# Altium schematic sheet LM5085.SchDoc
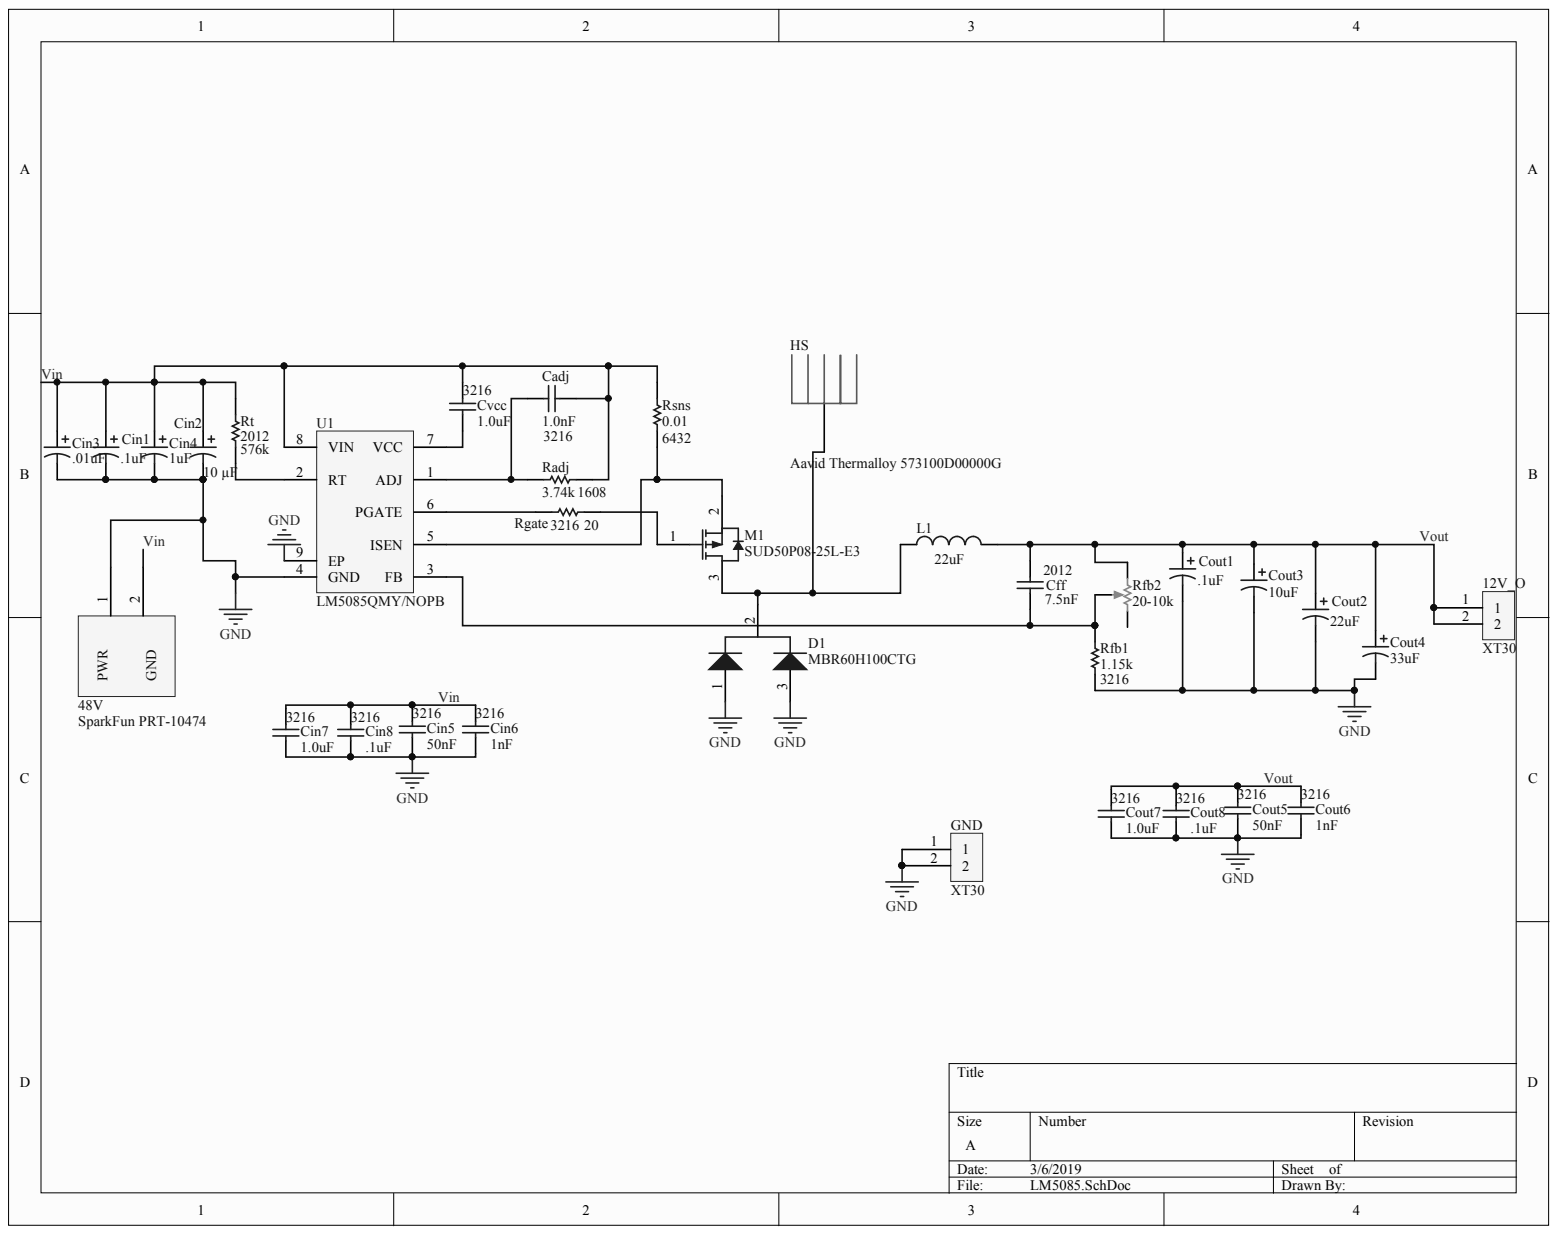
[diagram p0: LM5085.SchDoc]

## Parts
12V_O  XT30  [PCB-8h6goupi0sbkduz6cbnu-1]
48V  SparkFun PRT-10474  [PCB-0yod6kgj5trn1afdndpj-1]
Cadj  1.0nF  Case/Package (SI): 3216  [CAPC3216X94X50ML20T25]
Cff  Capacitance: 7.5nF  Case/Package (SI): 2012  [CAPC2013X95X45LL10T25]  (hidden comment: Murata GRM2195C1H752JA01D)
Cin1  Capacitance: .1uF  [PCB-2jf9nlde2fxplectirwc-1]  (hidden comment: Cap Pol2)
Cin2  AVX TAP106K010SCS  [PCB-qqn37rirklfs29ms14yu-1]  (value not drawn)
Cin3  Capacitance: .01uF  [PCB-2jf9nlde2fxplectirwc-1]  (hidden comment: Cap Pol2)
Cin4  Capacitance: 1uF  [PCB-2jf9nlde2fxplectirwc-1]  (hidden comment: Cap Pol2)
Cin5  50nF  Case/Package (SI): 3216  [CAPC3216X178X50LL20T25]
Cin6  1nF  Case/Package (SI): 3216  [CAPC3216X178X50LL20T25]
Cin7  1.0uF  Case/Package (SI): 3216  [CAPC3216X178X50LL20T25]
Cin8  .1uF  Case/Package (SI): 3216  [CAPC3216X178X50LL20T25]
Cout1  Capacitance: .1uF  [PCB-2jf9nlde2fxplectirwc-1]  (hidden comment: Cap Pol2)
Cout2  Capacitance: 22uF  [PCB-2jf9nlde2fxplectirwc-1]  (hidden comment: Cap Pol2)
Cout3  10uF  [PCB-qqn37rirklfs29ms14yu-1]
Cout4  33uF  [PCB-qqn37rirklfs29ms14yu-1]
Cout5  50nF  Case/Package (SI): 3216  [CAPC3216X178X50LL20T25]
Cout6  1nF  Case/Package (SI): 3216  [CAPC3216X178X50LL20T25]
Cout7  1.0uF  Case/Package (SI): 3216  [CAPC3216X178X50LL20T25]
Cout8  .1uF  Case/Package (SI): 3216  [CAPC3216X178X50LL20T25]
Cvcc  1.0uF  Case/Package (SI): 3216  [CAPC3216X178X50LL20T25]
D1  MBR60H100CTG  [ONSC-TO-220-3-221A-09_AF]
GND  XT30  [PCB-8h6goupi0sbkduz6cbnu-1]
HS  Aavid Thermalloy 573100D00000G  [PCB-4l8lw74ftxus1g73bafu-1]
L1  value 22uF  [PCB-wkoctkam4e9j5f7ferj6-1]  (hidden comment: ourns 2305-H-RC)
M1  SUD50P08-25L-E3  [DPAK228P991X238-3N]
Radj  3.74k  Case/Package (SI): 1608  [PCB-ar0da41b7xowg15jx8sr-1]
Rfb1  Case/Package (SI): 3216  Resistance: 1.15k  [RESC3216X70X50ML05T20]  (hidden comment: Panasonic ERJ-8ENF1151V)
Rfb2  20-10k  [PCB-wc6scyizddradfuod10o-1]
Rgate  Case/Package (SI): 3216  [RESC3216X70X50ML05T20]  (hidden comment: Panasonic ERJ-8ENF1151V)
Rsns  0.01  Package/Case (SI): 6432  [Resistor]
Rt  Case/Package (SI): 2012  Resistance: 576k  [RESC2013X70X40ML20T20]
U1  LM5085QMY/NOPB  [MUY08A_M]

## Nets
GND: 48V.1 Cin1.2 Cin2.2 Cin3.2 Cin4.2 Cin5.1 Cin6.1 Cin7.1 Cin8.1 Cout1.2 Cout2.2 Cout3.2 Cout4.2 Cout5.1 Cout6.1 Cout7.1 Cout8.1 D1.1 D1.3 GND.1 GND.2 Rfb1.2 U1.4(GND) U1.9(EP)
VIN: 48V.2 Cadj.2 Cin1.1 Cin2.1 Cin3.1 Cin4.1 Cin5.2 Cin6.2 Cin7.2 Cin8.2 Cvcc.2 Radj.2 Rsns.2 Rt.2 U1.8(VIN)
VOUT: 12V_O.1 12V_O.2 Cff.2 Cout1.1 Cout2.1 Cout3.1 Cout4.1 Cout5.2 Cout6.2 Cout7.2 Cout8.2 L1.2 Rfb2.1
NetCadj_1: Cadj.1 Radj.1 U1.1(ADJ)
NetCff_1: Cff.1 Rfb1.1 Rfb2.2 U1.3(FB)
NetCvcc_1: Cvcc.1 U1.7(VCC)
NetD1_2: D1.2 HS.0 L1.1 M1.3
NetM1_1: M1.1 Rgate.2
NetM1_2: M1.2 Rsns.1 U1.5(ISEN)
NetRgate_1: Rgate.1 U1.6(PGATE)
NetRt_1: Rt.1 U1.2(RT)

Not connected: Rfb2 3

## Library data of the parts
12V_O GND = CMP-nlx8yci0umf6n9sk0ty3-5: Very similar to the XT60 models, but smaller and made for 30A; Component Kind: Standard; CustomComponentID: nlx8yci0umf6n9sk0ty3; Library Name: CommunityVault
48V = CMP-d8151592827e07d2-4: XT60 Connectors Male/female Pair; Component Kind: Standard; Library Name: CommunityVault
Cadj = CMP-126200-2: CAP CER 1000PF 50V 10% X7R 1206; Manufacturer Part Number: 12065C102KAT2A; Capacitance: 1e-09 F; Dielectric Material: Ceramic Multilayer; Lead-Free Status: Lead Free; Voltage Rating (DC): 50.0 V; Capacitance Tolerance: ±10%; Case/Package: 1206; Component Kind: Standard; Dielectric Characteristic: X7R; Library Name: CommunityVault; Mounting Style: Surface Mount; Number of Pins: 2; OctopartID: 640faf5fad7ebbc8; Octopart Url: https://octopart.com/12065c102kat2a-avx+interconnect+%2F+elco-39513111; REACH SVHC Compliance: No SVHC; RoHS: Compliant; Size-Height: 0.00094 m; Size-Length: 0.0032 m; Size-Width: 0.0016 m
Cff = CMP-128280-2: CAP CER 7500PF 50V 5% NP0 0805; Dielectric Characteristic: C0G/NP0; Lead-Free Status: Lead Free; Voltage Rating (DC): 50.0 V; Capacitance Tolerance: ±5%; Case/Package: 0805; Component Kind: Standard; Library Name: CommunityVault; Lifecycle Status: Active; Manufacturer Part Number: GRM2195C1H752JA01D; Mounting Style: Surface Mount; OctopartID: a1d068d87bb77e17; Octopart Url: https://octopart.com/grm2195c1h752ja01d-murata-196353; RoHS: Compliant; Size-Height: 0.00085 m; Size-Length: 0.002 m; Size-Thickness: 0.00085 m; Size-Width: 0.00125 m
Cin1 Cin3 Cin4 Cout1 Cout2 = CMP-1b08d3184bfdc4cf-1: Cap Aluminum Lytic 100uF 16V 20% (6.3 X 11.2mm) Radial 2.5mm 290mA 1000h 105C Ammo Pack; Component Kind: Standard; Library Name: CommunityVault; Lifecycle Status: Not Listed by Manufacturer; Octopart URL: http://octopart.com/eeu-fc1c101h-panasonic-18511251
Cin2 = CMP-9beb52e18eb2442c-3: Capacitor; Tantalum; 10uF; Tap Series; Radial; Case C; 10%; 10V; 13VDC; 5 Ohms ESR; 0; Manufacturer: AVX Corporation; Capacitance: 10 µF; Equivalent Series Resistance (ESR): 5 Ω; Lead-Free Status: Contains Lead; Capacitance Tolerance: ±10%; Component Kind: Standard; Library Name: CommunityVault; Manufacturer Part Number: TAP106K010SCS; Mounting Style: Through Hole; Number of Pins: 2; OctopartID: 9beb52e18eb2442c; Octopart URL: http://octopart.com/tap106k010scs-avx-12351; Packaging: Bag; RoHS: Compliant; Size-Diameter: 5.08 mm; Size-Height: 8.38 mm; Voltage Rating (DC): 10 V
Cin5-Cin8 Cout5-Cout8 Cvcc = CMP-127284-2: CAP CER 1UF 50V 10% X7R 1206; Manufacturer Part Number: 12065C105KAT2A; Capacitance: 1e-06 F; Dielectric Material: Ceramic Multilayer; Lead-Free Status: Lead Free; Voltage Rating (DC): 50.0 V; Capacitance Tolerance: ±10%; Case/Package: 1206; Component Kind: Standard; Dielectric Characteristic: X7R; Library Name: CommunityVault; Mounting Style: Surface Mount; Number of Pins: 2; OctopartID: 506d991562b08faa; Octopart Url: https://octopart.com/12065c105kat2a-avx+interconnect+%2F+elco-7477179; REACH SVHC Compliance: No SVHC; RoHS: Compliant
Cout3 Cout4 = CMP-9beb52e18eb2442c-3: Capacitor; Tantalum; 10uF; Tap Series; Radial; Case C; 10%; 10V; 13VDC; 5 Ohms ESR; 0; Manufacturer: AVX Corporation; Manufacturer Part Number: TAP106K010SCS; Capacitance: 10 µF; Equivalent Series Resistance (ESR): 5 Ω; Lead-Free Status: Contains Lead; Capacitance Tolerance: ±10%; Component Kind: Standard; Library Name: CommunityVault; Mounting Style: Through Hole; Number of Pins: 2; OctopartID: 9beb52e18eb2442c; Octopart URL: http://octopart.com/tap106k010scs-avx-12351; Packaging: Bag; RoHS: Compliant; Size-Diameter: 5.08 mm; Size-Height: 8.38 mm; Voltage Rating (DC): 10 V
D1 = CMP-811200-2: SWITCHMODE(TM) Power Rectifier, 100 V, 60 A, 3-Pin TO-220, Pb-Free, Tube; Current Rating: 60.0 A; Forward Voltage: 0.98 V; Lead-Free Status: Lead Free; Voltage Rating (DC): 100.0 V; Case/Package: TO-220-3; Component Kind: Standard; Library Name: CommunityVault; Lifecycle Status: Active; Manufacturer Part Number: MBR60H100CTG; Mounting Style: Through Hole; Number of Pins: 3; OctopartID: a92a602a58ff76ed; Octopart Url: https://octopart.com/mbr60h100ctg-on+semiconductor-709811; Packaging: Tube; REACH SVHC Compliance: No SVHC; RoHS: Compliant
HS = CMP-e04a294c96133f8d-2: TO-252 Surface Mount 15.00 C/W Thermal Resistance D2 Semiconductor Heat Sink; Lead-Free Status: Lead Free; Thermal Resistance: 15.0 K/W; Component Kind: Standard; Library Name: CommunityVault; OctopartID: e04a294c96133f8d; Packaging: Box; Size-Height: 10.2 mm
L1 = CMP-543c9f6dab498bfb-2: Inductor, High Current Toroidal, 22UH, 0.007 Ohm Dcr Max, Horizontal Mount; Component Kind: Standard; Library Name: CommunityVault
M1 = CMP-75eabe6657ccaa2d-1: Single P-Channel 80 V 0.0252 Ohms Surface Mount Power Mosfet - TO-252; Lead-Free Status: Lead Free; Component Kind: Standard; Library Name: CommunityVault; Manufacturer Part Number: SUD50P08-25L-E3; Mounting Style: Surface Mount; Number of Pins: 3; OctopartID: 75eabe6657ccaa2d; Octopart URL: https://octopart.com/sud50p08-25l-e3-vishay-42338112; Operating Temperature: 175 °C (max); Packaging: Reel; Polarity: P-Channel; RoHS: Compliant
Radj = CMP-3ca7f596181de360-10: Res Thick Film 0603 4.7K Ohm 1% 1/10W ±100ppm/°C Molded SMD SMD Paper Tape on Plastic Reel; Manufacturer Part Number: CR0603-FX-4701ELF; Resistance: 4.70 kΩ; Temperature Coefficient: -100 ppm/°C to 100 ppm/°C; Voltage Rating (DC): 50.0 V; Component Kind: Standard; Library Name: CommunityVault; Mounting Style: Surface Mount; Packaging: Tape & Reel (TR), Cut Tape (CT); Size-Length: 1.60 mm
Rfb1 = CMP-3676977-2: Thick Film Resistors - SMD 1206 1.15Kohms 1% Tolerance; Lead-Free Status: Lead Free; Power Rating: 0.25 W; Voltage Rating (DC): 200.0 V; Case/Package: 1206; Component Kind: Standard; Library Name: CommunityVault; Lifecycle Status: Not Listed by Manufacturer; Manufacturer Part Number: ERJ-8ENF1151V; Mounting Style: Surface Mount; OctopartID: edb2263d136b3275; Octopart Url: https://octopart.com/erj-8enf1151v-panasonic-42326975; REACH SVHC Compliance: No SVHC; Resistance Tolerance: ±1%; RoHS: Compliant; Size-Height: 0.0006 m; Size-Length: 0.002 m; Size-Width: 0.0016 m
Rfb2 = CMP-9d2d547574b442ea-5: P090 Series 9 mm Top Adjustment 10 kOhm ±20 % Shaft Rotary Potentiometer; Manufacturer Part Number: P090S-14T20BR10K; Lead-Free Status: Lead Free; Power Rating: 30.0 mW; Resistance Tolerance: ±20%; Component Kind: Standard; Library Name: CommunityVault; OctopartID: 9d2d547574b442ea; Octopart URL: http://octopart.com/p090s-14t20br10k-bi+technologies-5426431; RoHS: Compliant
Rgate = CMP-3676977-2: Thick Film Resistors - SMD 1206 1.15Kohms 1% Tolerance; Lead-Free Status: Lead Free; Power Rating: 0.25 W; Resistance: 20; Voltage Rating (DC): 200.0 V; Case/Package: 1206; Component Kind: Standard; Library Name: CommunityVault; Lifecycle Status: Not Listed by Manufacturer; Manufacturer Part Number: ERJ-8ENF1151V; Mounting Style: Surface Mount; OctopartID: edb2263d136b3275; Octopart Url: https://octopart.com/erj-8enf1151v-panasonic-42326975; REACH SVHC Compliance: No SVHC; Resistance Tolerance: ±1%; RoHS: Compliant; Size-Height: 0.0006 m; Size-Length: 0.002 m; Size-Width: 0.0016 m
Rsns = CMP-ba89712ce229654e-1: SMD Current Sense Resistors, LRMA Series, 0.01 ohm, 3 W, - 1%, 2512 [6432 Metric]; Manufacturer Part Number: LRMAP2512-R01FT4; Lead-Free Status: Lead Free; Power Rating: 2.00 W; Component Kind: Standard; Library Name: CommunityVault; Mounting Style: Surface Mount; OctopartID: ba89712ce229654e; Octopart URL: http://octopart.com/lrmap2512-r01ft4-welwyn-45055430; Packaging: Tape & Reel (TR), Cut Tape (CT); REACH SVHC Compliance: No SVHC; Resistance Tolerance: ±1%; RoHS: Compliant
Rt = CMP-3653159-2: Thick Film Resistors - SMD 0805 56Kohms 5% Tol; Manufacturer Part Number: ERJ-6GEYJ563V; Lead-Free Status: Lead Free; Power Rating: 0.125 W; Voltage Rating (DC): 150.0 V; Case/Package: 0805; Component Kind: Standard; Library Name: CommunityVault; Lifecycle Status: Not Listed by Manufacturer; Mounting Style: Surface Mount; OctopartID: ac0d664a395c73ca; Octopart Url: https://octopart.com/erj-6geyj563v-panasonic-55423031; Packaging: Cut Tape (CT); REACH SVHC Compliance: No SVHC; Resistance Tolerance: ±5%; RoHS: Compliant; Size-Height: 0.0006 m; Size-Length: 0.002 m; Size-Width: 0.00125 m
U1 = CMP-0069-01314-3: 75V Constant On-Time PFET Buck Switching Controller, 8-pin eMSOP, Pb-Free; Lead-Free Status: Lead Free; Number of Regulated Outputs: 1; Quiescent Current: 0.0013 A; Component Kind: Standard; Library Name: CommunityVault; Lifecycle Status: Active; Manufacturer Part Number: LM5085QMY/NOPB; OctopartID: 97a85fd9b4b9486f; Octopart Url: https://octopart.com/lm5085qmy%2Fnopb-texas+instruments-24839799; RoHS: Compliant; Topology: Buck
